# Altium schematic sheet 电机.SchDoc
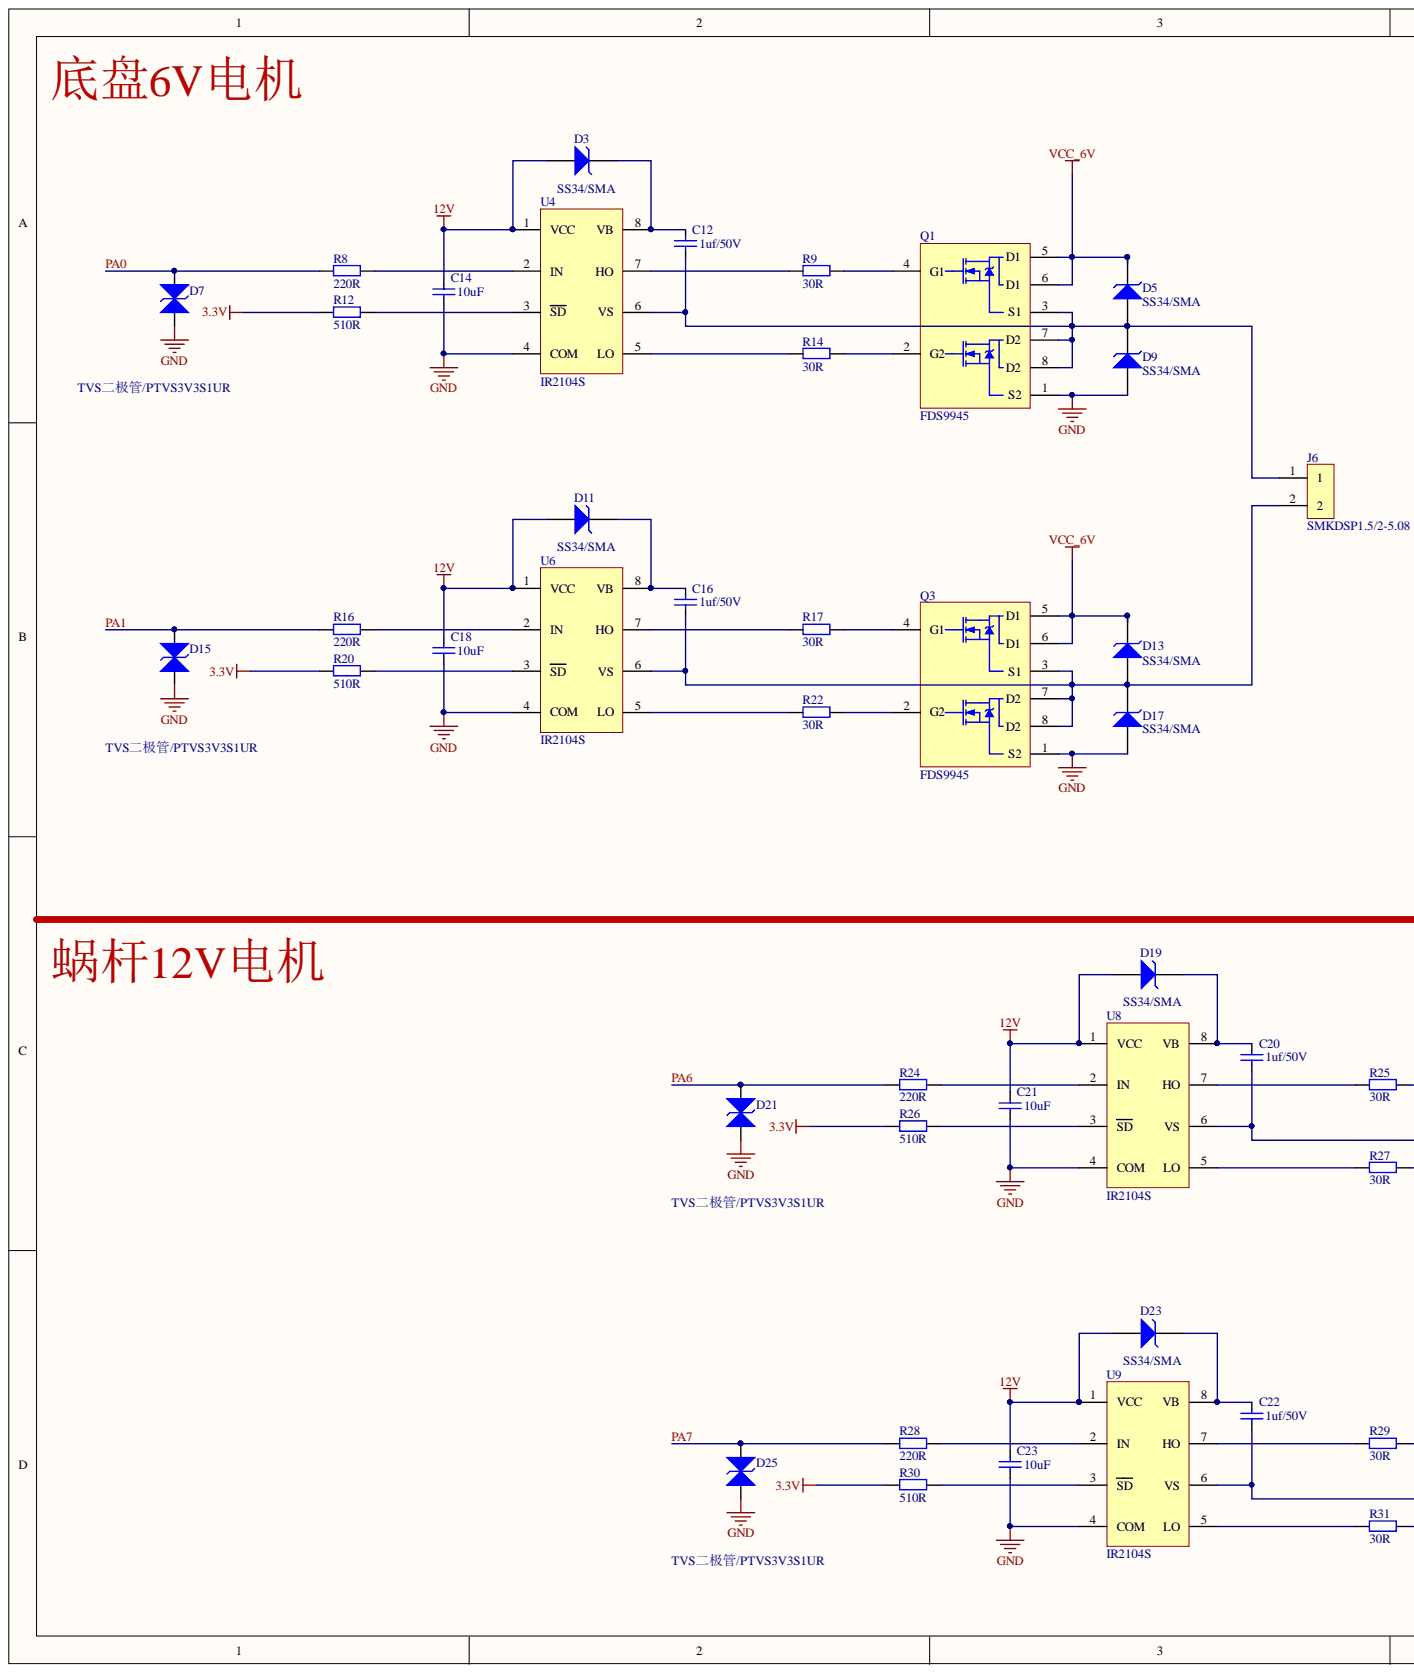
[diagram p0: 电机.SchDoc, left]
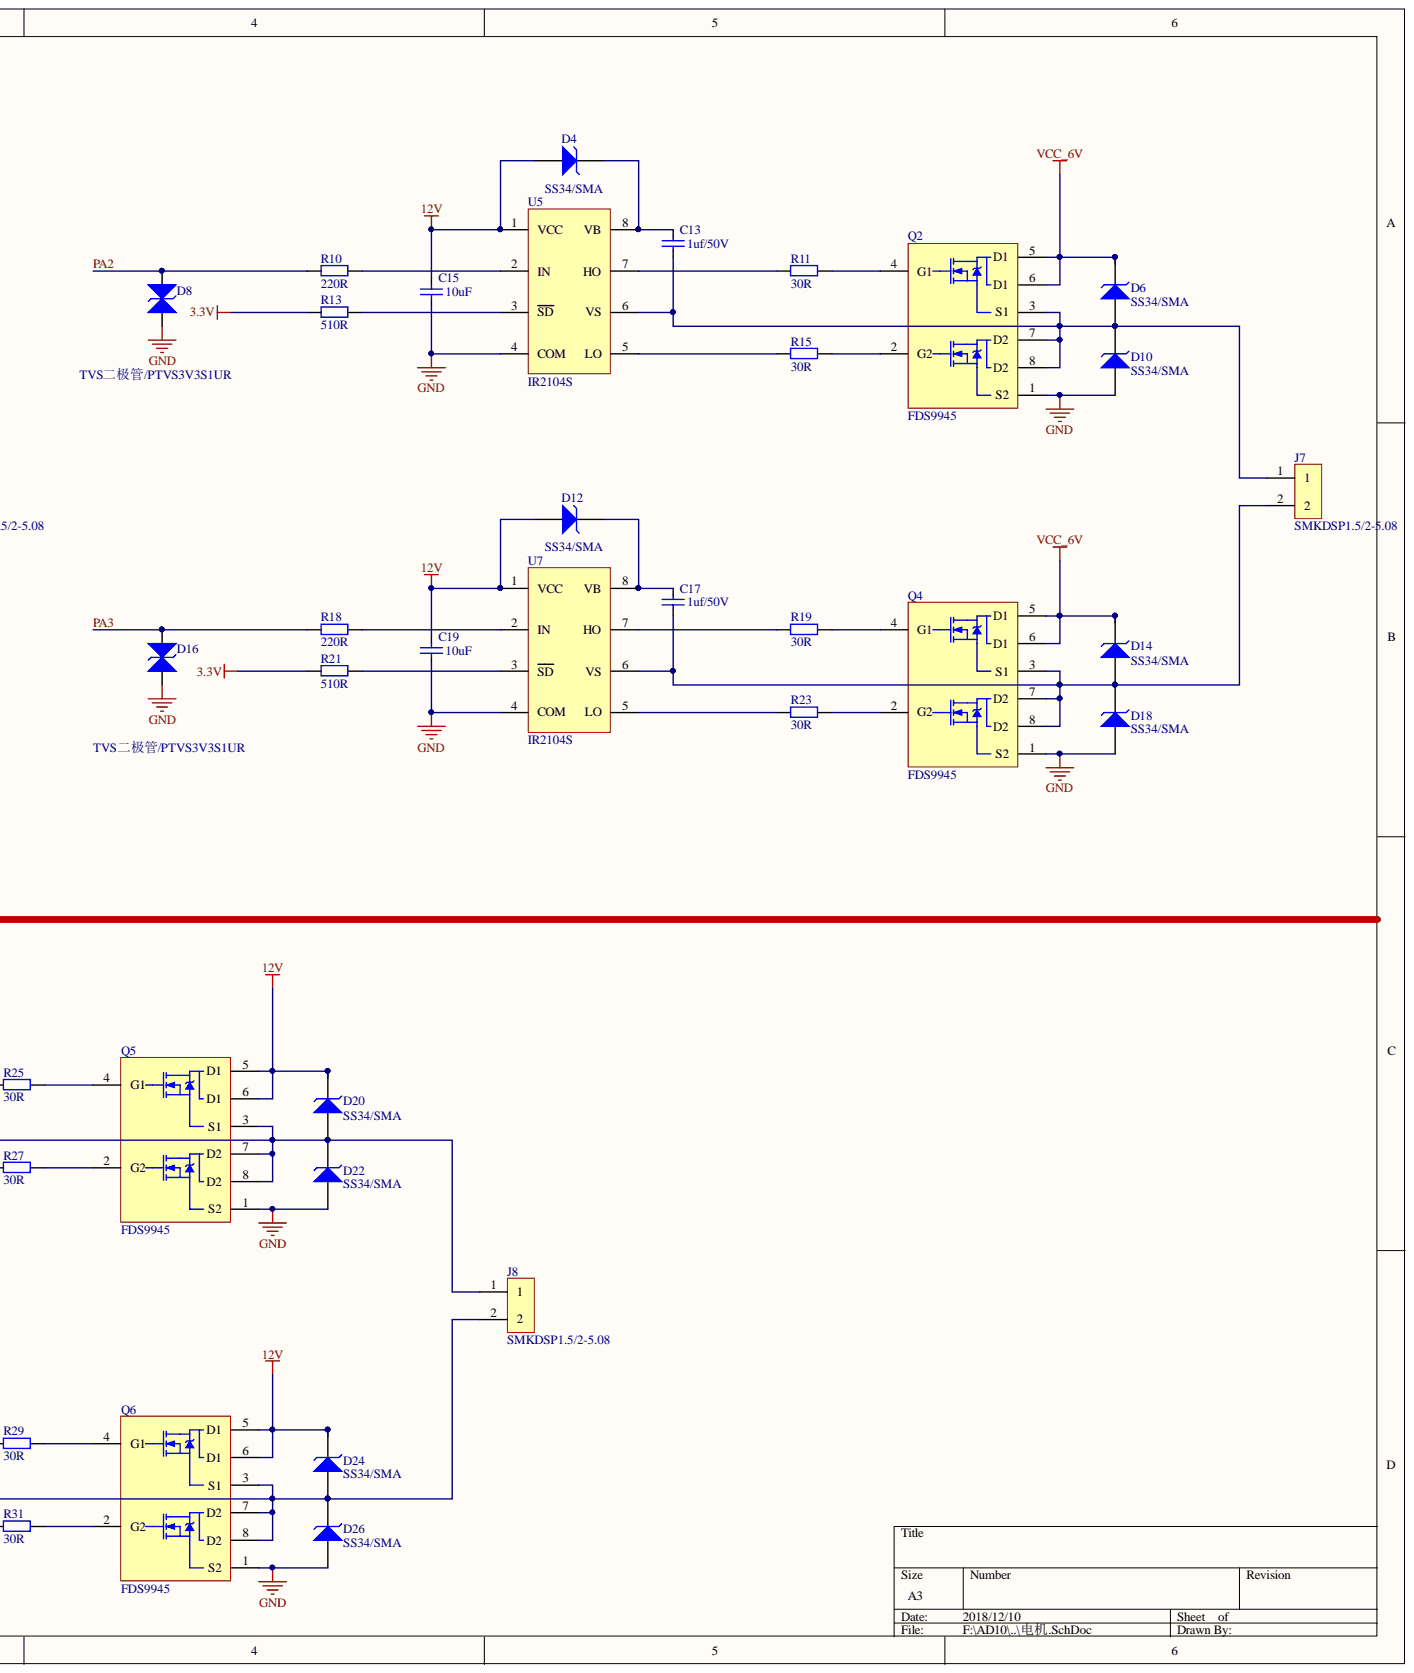
[diagram p1: 电机.SchDoc, right]

## Parts
C12  1uf/50V  [LC-0603_C]
C13  1uf/50V  [LC-0603_C]
C14  10uF  [LC-0603_C]
C15  10uF  [LC-0603_C]
C16  1uf/50V  [LC-0603_C]
C17  1uf/50V  [LC-0603_C]
C18  10uF  [LC-0603_C]
C19  10uF  [LC-0603_C]
C20  1uf/50V  [LC-0603_C]
C21  10uF  [LC-0603_C]
C22  1uf/50V  [LC-0603_C]
C23  10uF  [LC-0603_C]
D3  SS34/SMA  [LC-SMA(DO-214AC)_S1]
D4  SS34/SMA  [LC-SMA(DO-214AC)_S1]
D5  SS34/SMA  [LC-SMA(DO-214AC)_S1]
D6  SS34/SMA  [LC-SMA(DO-214AC)_S1]
D7  TVS二极管/PTVS3V3S1UR  [LC-SOD-123]
D8  TVS二极管/PTVS3V3S1UR  [LC-SOD-123]
D9  SS34/SMA  [LC-SMA(DO-214AC)_S1]
D10  SS34/SMA  [LC-SMA(DO-214AC)_S1]
D11  SS34/SMA  [LC-SMA(DO-214AC)_S1]
D12  SS34/SMA  [LC-SMA(DO-214AC)_S1]
D13  SS34/SMA  [LC-SMA(DO-214AC)_S1]
D14  SS34/SMA  [LC-SMA(DO-214AC)_S1]
D15  TVS二极管/PTVS3V3S1UR  [LC-SOD-123]
D16  TVS二极管/PTVS3V3S1UR  [LC-SOD-123]
D17  SS34/SMA  [LC-SMA(DO-214AC)_S1]
D18  SS34/SMA  [LC-SMA(DO-214AC)_S1]
D19  SS34/SMA  [LC-SMA(DO-214AC)_S1]
D20  SS34/SMA  [LC-SMA(DO-214AC)_S1]
D21  TVS二极管/PTVS3V3S1UR  [LC-SOD-123]
D22  SS34/SMA  [LC-SMA(DO-214AC)_S1]
D23  SS34/SMA  [LC-SMA(DO-214AC)_S1]
D24  SS34/SMA  [LC-SMA(DO-214AC)_S1]
D25  TVS二极管/PTVS3V3S1UR  [LC-SOD-123]
D26  SS34/SMA  [LC-SMA(DO-214AC)_S1]
J6  SMKDSP1.5/2-5.08  [电源接线口5.08]
J7  SMKDSP1.5/2-5.08  [电源接线口5.08]
J8  SMKDSP1.5/2-5.08  [电源接线口5.08]
Q1  FDS9945  [LC-SOP-8_150mil]
Q2  FDS9945  [LC-SOP-8_150mil]
Q3  FDS9945  [LC-SOP-8_150mil]
Q4  FDS9945  [LC-SOP-8_150mil]
Q5  FDS9945  [LC-SOP-8_150mil]
Q6  FDS9945  [LC-SOP-8_150mil]
R8  220R  [LC-0603_R]
R9  30R  [LC-0603_R]
R10  220R  [LC-0603_R]
R11  30R  [LC-0603_R]
R12  510R  [LC-0603_R]
R13  510R  [LC-0603_R]
R14  30R  [LC-0603_R]
R15  30R  [LC-0603_R]
R16  220R  [LC-0603_R]
R17  30R  [LC-0603_R]
R18  220R  [LC-0603_R]
R19  30R  [LC-0603_R]
R20  510R  [LC-0603_R]
R21  510R  [LC-0603_R]
R22  30R  [LC-0603_R]
R23  30R  [LC-0603_R]
R24  220R  [LC-0603_R]
R25  30R  [LC-0603_R]
R26  510R  [LC-0603_R]
R27  30R  [LC-0603_R]
R28  220R  [LC-0603_R]
R29  30R  [LC-0603_R]
R30  510R  [LC-0603_R]
R31  30R  [LC-0603_R]
U4  IR2104S  [LC-SOP-8_150mil]
U5  IR2104S  [LC-SOP-8_150mil]
U6  IR2104S  [LC-SOP-8_150mil]
U7  IR2104S  [LC-SOP-8_150mil]
U8  IR2104S  [LC-SOP-8_150mil]
U9  IR2104S  [LC-SOP-8_150mil]

## Nets
3.3V: R12.1 R13.1 R20.1 R21.1 R26.1 R30.1
12V: C14.2 C15.2 C18.2 C19.2 C21.2 C23.2 D3.1 D4.1 D11.1 D12.1 D19.1 D20.2 D23.1 D24.2 Q5.5(D1) Q5.6(D1) Q6.5(D1) Q6.6(D1) U4.1(VCC) U5.1(VCC) U6.1(VCC) U7.1(VCC) U8.1(VCC) U9.1(VCC)
GND: C14.1 C15.1 C18.1 C19.1 C21.1 C23.1 D7.1 D8.1 D9.1 D10.1 D15.1 D16.1 D17.1 D18.1 D21.1 D22.1 D25.1 D26.1 Q1.1(S2) Q2.1(S2) Q3.1(S2) Q4.1(S2) Q5.1(S2) Q6.1(S2) U4.4(COM) U5.4(COM) U6.4(COM) U7.4(COM) U8.4(COM) U9.4(COM)
VCC_6V: D5.2 D6.2 D13.2 D14.2 Q1.5(D1) Q1.6(D1) Q2.5(D1) Q2.6(D1) Q3.5(D1) Q3.6(D1) Q4.5(D1) Q4.6(D1)
PA0: D7.2 R8.1
PA1: D15.2 R16.1
PA2: D8.2 R10.1
PA3: D16.2 R18.1
PA6: D21.2 R24.1
PA7: D25.2 R28.1
NetC12_1: C12.1 D5.1 D9.2 J6.1 Q1.3(S1) Q1.7(D2) Q1.8(D2) U4.6(VS)
NetC12_2: C12.2 D3.2 U4.8(VB)
NetC13_1: C13.1 D6.1 D10.2 J7.1 Q2.3(S1) Q2.7(D2) Q2.8(D2) U5.6(VS)
NetC13_2: C13.2 D4.2 U5.8(VB)
NetC16_1: C16.1 D13.1 D17.2 J6.2 Q3.3(S1) Q3.7(D2) Q3.8(D2) U6.6(VS)
NetC16_2: C16.2 D11.2 U6.8(VB)
NetC17_1: C17.1 D14.1 D18.2 J7.2 Q4.3(S1) Q4.7(D2) Q4.8(D2) U7.6(VS)
NetC17_2: C17.2 D12.2 U7.8(VB)
NetC20_1: C20.1 D20.1 D22.2 J8.1 Q5.3(S1) Q5.7(D2) Q5.8(D2) U8.6(VS)
NetC20_2: C20.2 D19.2 U8.8(VB)
NetC22_1: C22.1 D24.1 D26.2 J8.2 Q6.3(S1) Q6.7(D2) Q6.8(D2) U9.6(VS)
NetC22_2: C22.2 D23.2 U9.8(VB)
NetQ1_2: Q1.2(G2) R14.2
NetQ1_4: Q1.4(G1) R9.2
NetQ2_2: Q2.2(G2) R15.2
NetQ2_4: Q2.4(G1) R11.2
NetQ3_2: Q3.2(G2) R22.2
NetQ3_4: Q3.4(G1) R17.2
NetQ4_2: Q4.2(G2) R23.2
NetQ4_4: Q4.4(G1) R19.2
NetQ5_2: Q5.2(G2) R27.2
NetQ5_4: Q5.4(G1) R25.2
NetQ6_2: Q6.2(G2) R31.2
NetQ6_4: Q6.4(G1) R29.2
NetR8_2: R8.2 U4.2(IN)
NetR9_1: R9.1 U4.7(HO)
NetR10_2: R10.2 U5.2(IN)
NetR11_1: R11.1 U5.7(HO)
NetR12_2: R12.2 U4.3(SD)
NetR13_2: R13.2 U5.3(SD)
NetR14_1: R14.1 U4.5(LO)
NetR15_1: R15.1 U5.5(LO)
NetR16_2: R16.2 U6.2(IN)
NetR17_1: R17.1 U6.7(HO)
NetR18_2: R18.2 U7.2(IN)
NetR19_1: R19.1 U7.7(HO)
NetR20_2: R20.2 U6.3(SD)
NetR21_2: R21.2 U7.3(SD)
NetR22_1: R22.1 U6.5(LO)
NetR23_1: R23.1 U7.5(LO)
NetR24_2: R24.2 U8.2(IN)
NetR25_1: R25.1 U8.7(HO)
NetR26_2: R26.2 U8.3(SD)
NetR27_1: R27.1 U8.5(LO)
NetR28_2: R28.2 U9.2(IN)
NetR29_1: R29.1 U9.7(HO)
NetR30_2: R30.2 U9.3(SD)
NetR31_1: R31.1 U9.5(LO)

## Library data of the parts
C12-C23 = Cap_1206: Component Kind: Standard; Description: Cap_1206; Library Name: 工作原理库.SchLib
D3-D6 D9-D14 D17-D20 D22-D24 D26 = 1N5822/SS34/SMA肖特基二极管: Component Kind: Standard; Library Name: 工作原理库.SchLib
D7 D8 D15 D16 D21 D25 = 双向TVS管: Component Kind: Standard; Library Name: 工作原理库.SchLib
J6-J8 = CON2: Component Kind: Standard; Library Name: 工作原理库.SchLib
Q1-Q6 = FDS9945: FDS9945/两路Nmos管; Component Kind: Standard; Library Name: 工作原理库.SchLib
R8 R10 R12 R13 R16 R18 R20 R21 R24 R26 R28 R30 = Res_0603: Component Kind: Standard; Description: Res_0603; Value: Res_0603; Library Name: 工作原理库.SchLib
R9 R11 R14 R15 R17 R19 R22 R23 R25 R27 R29 R31 = Res_1206: Value: Res_0805; Component Kind: Standard; Description: Res_1206; LatestRevisionDate: 17-Jul-2002; LatestRevisionNote: Re-released for DXP Platform.; PackageReference: AXIAL-0.4; Published: 8-Jun-2000; Library Name: 工作原理库.SchLib; Publisher: Altium Limited
U4-U9 = IR2104S: IR2104S/SOIC-8_150mil; Component Kind: Standard; Library Name: 工作原理库.SchLib
